# Altium schematic sheet AKK_V3.SchDoc
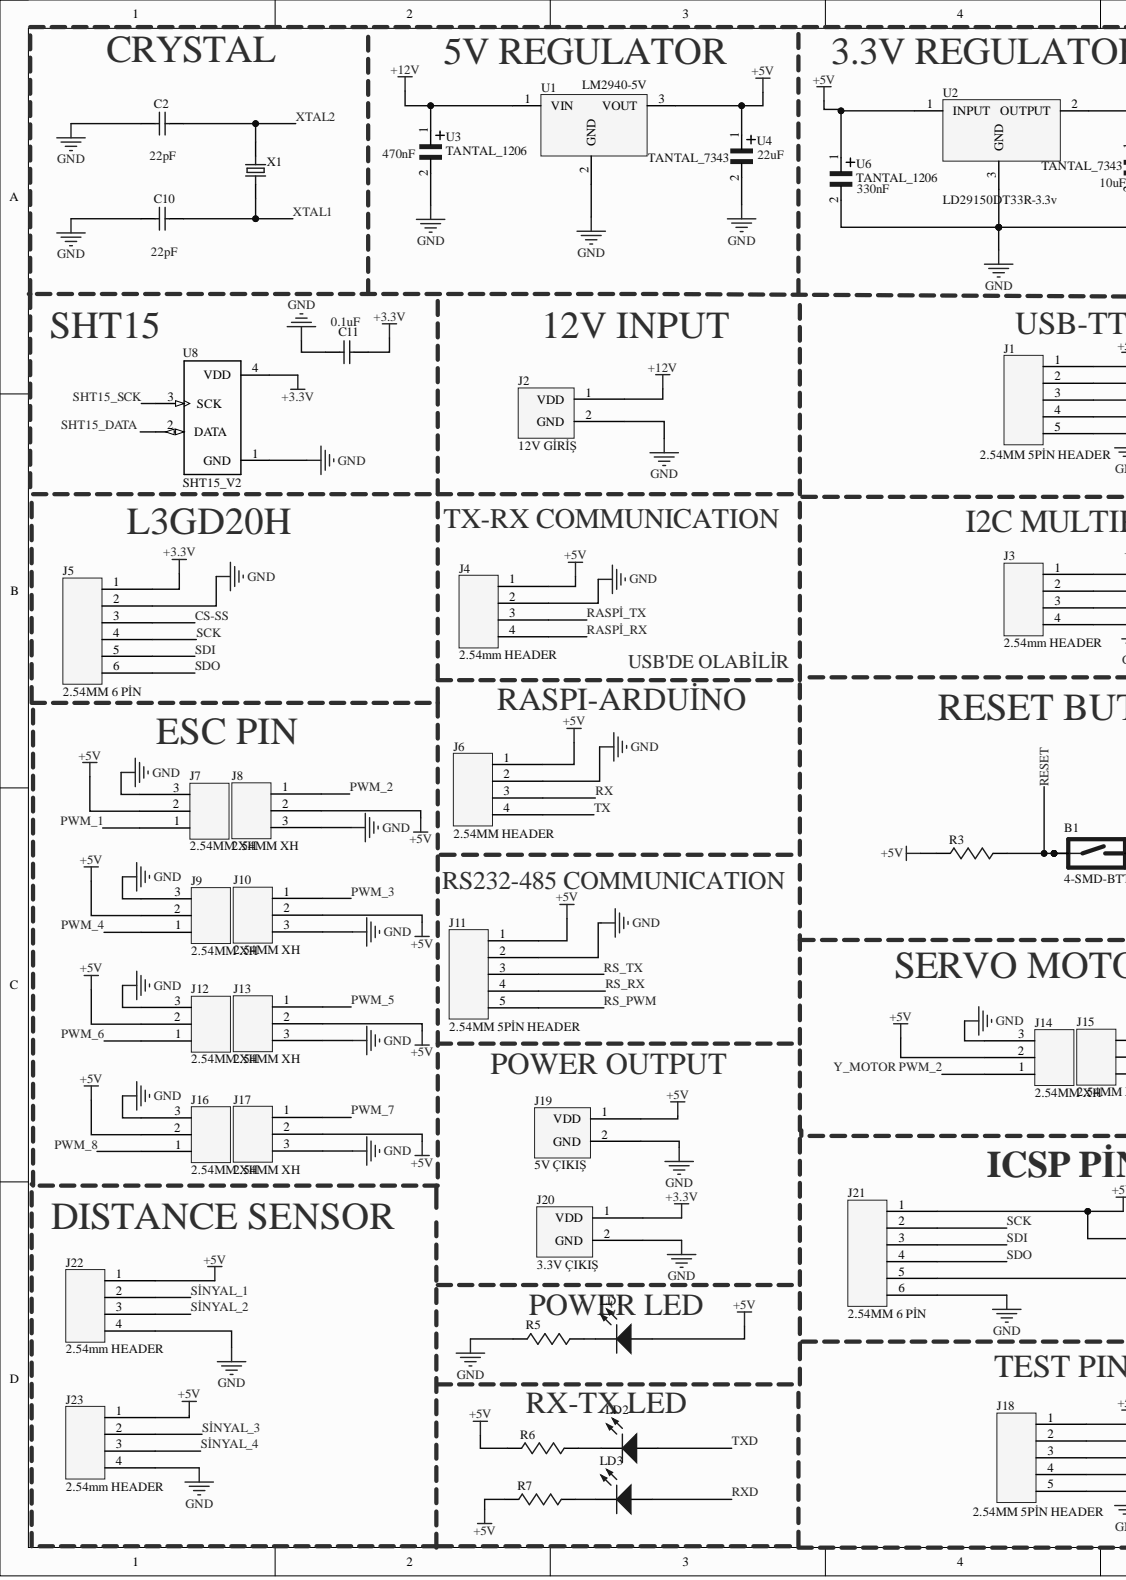
[diagram p0: AKK_V3.SchDoc, left]
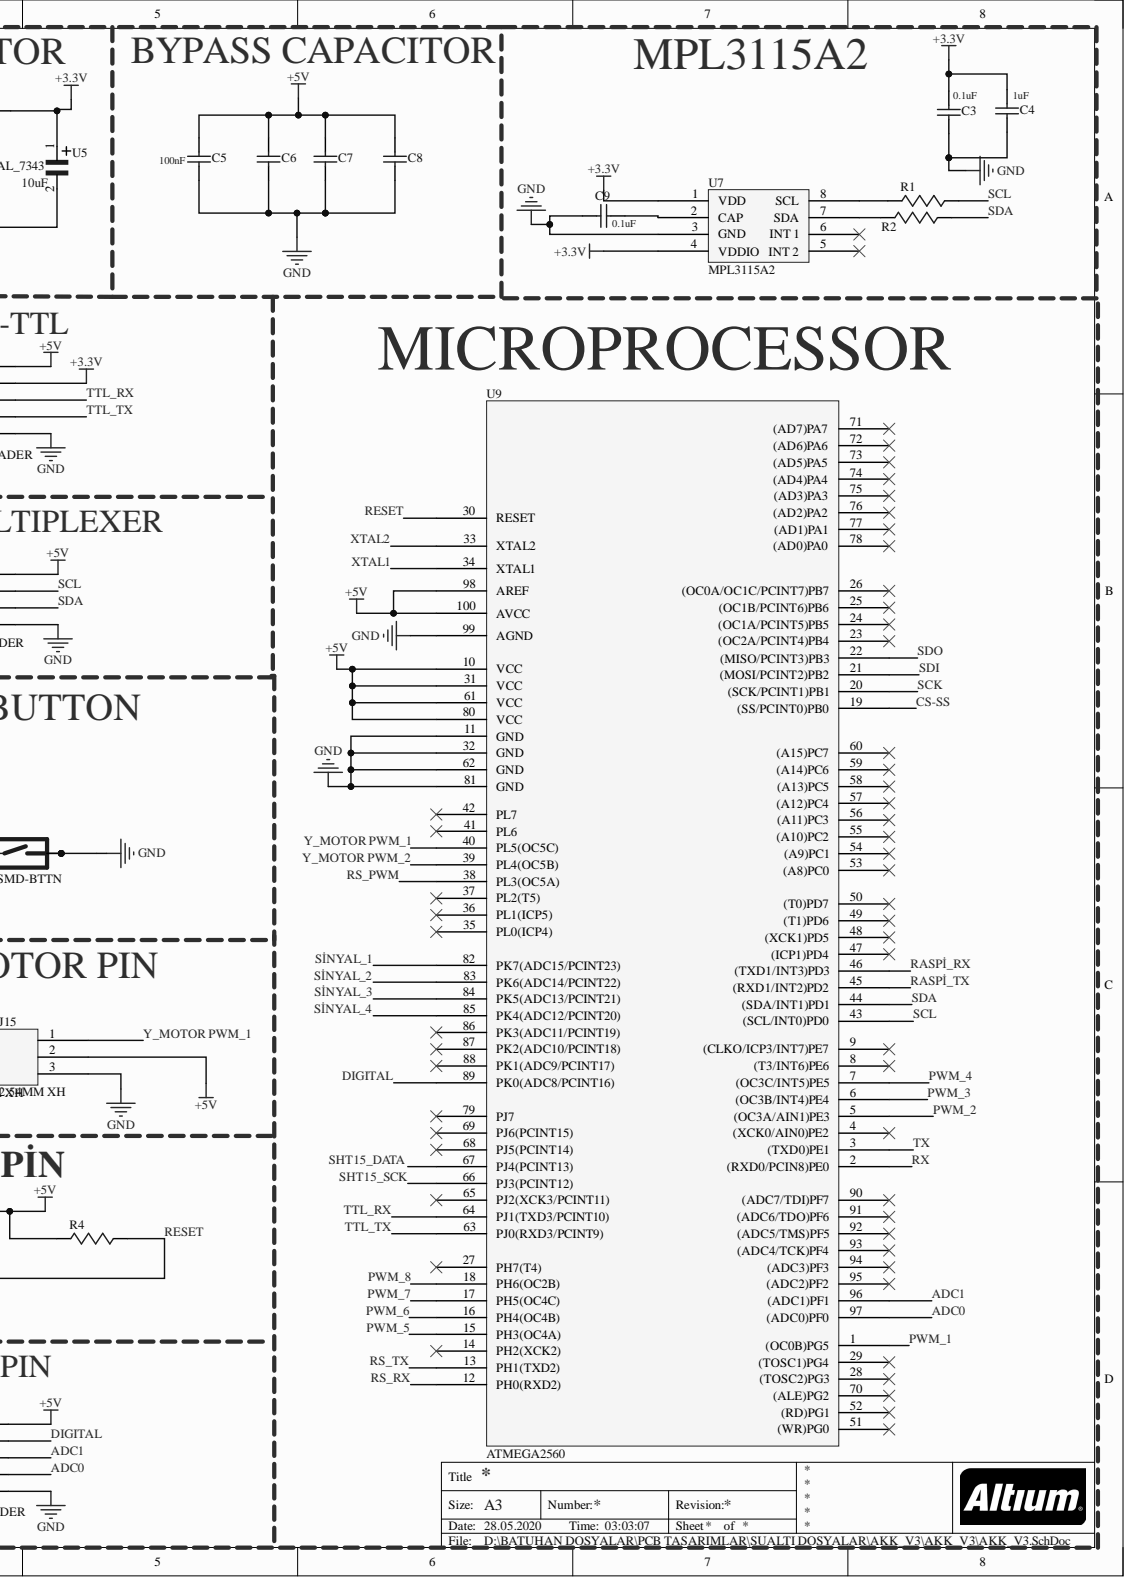
[diagram p1: AKK_V3.SchDoc, right]

## Parts
B1  4-SMD-BTTN  [4-SMD-BTTN-11.6-6.5]
C2  =Value  [C1206N]
C3  =Value  [C1206N]
C4  =Value  [C1206N]
C5  Capacitance: 100nF  [C1206N]
C6  =Value  [C1206N]
C7  =Value  [C1206N]
C8  =Value  [C1206N]
C9  =Value  [C1206N]
C10  =Value  [C1206N]
C11  =Value  [C1206N]
J1  2.54MM 5PİN HEADER  [2.54MM_5PİN_HEADER]
J2  12V GİRİŞ  [KLEMENS_5MM]
J3  2.54mm HEADER  [2.54MM_HEADER]
J4  2.54mm HEADER  [2.54MM_HEADER]
J5  2.54MM 6 PİN  [2.54mm 6 pin]
J6  2.54MM HEADER  [2.54MM_HEADER]
J7  2.54MM XH  [XH 2.54MM 3 PİN]
J8  2.54MM XH  [XH 2.54MM 3 PİN]
J9  2.54MM XH  [XH 2.54MM 3 PİN]
J10  2.54MM XH  [XH 2.54MM 3 PİN]
J11  2.54MM 5PİN HEADER  [2.54MM_5PİN_HEADER]
J12  2.54MM XH  [XH 2.54MM 3 PİN]
J13  2.54MM XH  [XH 2.54MM 3 PİN]
J14  2.54MM XH  [XH 2.54MM 3 PİN]
J15  2.54MM XH  [XH 2.54MM 3 PİN]
J16  2.54MM XH  [XH 2.54MM 3 PİN]
J17  2.54MM XH  [XH 2.54MM 3 PİN]
J18  2.54MM 5PİN HEADER  [2.54MM_5PİN_HEADER]
J19  5V ÇIKIŞ  [KLEMENS_5MM]
J20  3.3V ÇIKIŞ  [KLEMENS_5MM]
J21  2.54MM 6 PİN  [2.54mm 6 pin]
J22  2.54mm HEADER  [2.54MM_HEADER]
J23  2.54mm HEADER  [2.54MM_HEADER]
LD1  =Value  [1206-BLUE]
LD2  =Value  [1206-BLUE]
LD3  =Value  [1206-BLUE]
R1  =Value  [R1206N]
R2  =Value  [R1206N]
R3  =Value  [R1206N]
R4  =Value  [R1206N]
R5  =Value  [R1206N]
R6  =Value  [R1206N]
R7  =Value  [R1206N]
U1  LM2940-5V  Nominal Output Voltage: 5V  [TO220]
U2  LD29150DT33R-3.3v  Min Output Voltage: 3.3V  [TO252-DPAK]
U3  TANTAL_1206  [TANTAL_1206]
U4  TANTAL_7343  [TANTAL_7343]
U5  TANTAL_7343  [TANTAL_7343]
U6  TANTAL_1206  [TANTAL_1206]
U7  MPL3115A2  [MPL3115A2]
U8  SHT15_V2  [SHT15_V2]
U9  ATMEGA2560  [ATMEGA2560]
X1  =Value  [HC49]

## Nets
+3.3V: C3.2 C4.2 C11.1 J1.2 J5.1 J20.1 U2.2 U5.1 U7.1(VDD) U7.4(VDDIO) U8.4(VDD)
+5V: C5.2 C6.2 C7.2 C8.2 J1.1 J3.1 J4.1 J6.1 J7.2 J8.2 J9.2 J10.2 J11.1 J12.2 J13.2 J14.2 J15.2 J16.2 J17.2 J18.1 J19.1 J21.1 J22.1 J23.1 LD1.2 R3.1 R4.1 R6.1 R7.1 U1.3 U2.1 U4.1 U6.1 U9.10(VCC) U9.31(VCC) U9.61(VCC) U9.80(VCC) U9.98(AREF) U9.100(AVCC)
+12V: J2.1 U1.1 U3.1
GND: B1.1 B1.2 C2.2 C3.1 C4.1 C5.1 C6.1 C7.1 C8.1 C9.2 C10.2 C11.2 J1.5 J2.2 J3.4 J4.2 J5.2 J6.2 J7.3 J8.3 J9.3 J10.3 J11.2 J12.3 J13.3 J14.3 J15.3 J16.3 J17.3 J18.5 J19.2 J20.2 J21.6 J22.4 J23.4 R5.1 U1.2 U2.3 U3.2 U4.2 U5.2 U6.2 U7.3(GND) U8.1(GND) U9.11(GND) U9.32(GND) U9.62(GND) U9.81(GND) U9.99(AGND)
ADC0: J18.4 U9.97((ADC0)PF0)
ADC1: J18.3 U9.96((ADC1)PF1)
CS-SS: J5.3 U9.19((SS/PCINT0)PB0)
DIGITAL: J18.2 U9.89(PK0(ADC8/PCINT16))
PWM_1: J7.1 U9.1((OC0B)PG5)
PWM_2: J8.1 U9.5((OC3A/AIN1)PE3)
PWM_3: J10.1 U9.6((OC3B/INT4)PE4)
PWM_4: J9.1 U9.7((OC3C/INT5)PE5)
PWM_5: J13.1 U9.15(PH3(OC4A))
PWM_6: J12.1 U9.16(PH4(OC4B))
PWM_7: J17.1 U9.17(PH5(OC4C))
PWM_8: J16.1 U9.18(PH6(OC2B))
RASPİ_RX: J4.4 U9.46((TXD1/INT3)PD3)
RASPİ_TX: J4.3 U9.45((RXD1/INT2)PD2)
RESET: B1.3 B1.4 J21.5 R3.2 R4.2 U9.30(RESET)
RS_PWM: J11.5 U9.38(PL3(OC5A))
RS_RX: J11.4 U9.12(PH0(RXD2))
RS_TX: J11.3 U9.13(PH1(TXD2))
RX: J6.3 U9.2((RXD0/PCIN8)PE0)
RXD: LD3.2
SCK: J5.4 J21.2 U9.20((SCK/PCINT1)PB1)
SCL: J3.2 R1.2 U9.43((SCL/INT0)PD0)
SDA: J3.3 R2.2 U9.44((SDA/INT1)PD1)
SDI: J5.5 J21.3 U9.21((MOSI/PCINT2)PB2)
SDO: J5.6 J21.4 U9.22((MISO/PCINT3)PB3)
SHT15_DATA: U8.2(DATA) U9.67(PJ4(PCINT13))
SHT15_SCK: U8.3(SCK) U9.66(PJ3(PCINT12))
SİNYAL_1: J22.2 U9.82(PK7(ADC15/PCINT23))
SİNYAL_2: J22.3 U9.83(PK6(ADC14/PCINT22))
SİNYAL_3: J23.2 U9.84(PK5(ADC13/PCINT21))
SİNYAL_4: J23.3 U9.85(PK4(ADC12/PCINT20))
TTL_RX: J1.3 U9.64(PJ1(TXD3/PCINT10))
TTL_TX: J1.4 U9.63(PJ0(RXD3/PCINT9))
TX: J6.4 U9.3((TXD0)PE1)
TXD: LD2.2
XTAL1: C10.1 U9.34(XTAL1) X1.1
XTAL2: C2.1 U9.33(XTAL2) X1.2
Y_MOTOR PWM_1: J15.1 U9.40(PL5(OC5C))
Y_MOTOR PWM_2: J14.1 U9.39(PL4(OC5B))
NetC9_1: C9.1 U7.2(CAP)
NetLD1_1: LD1.1 R5.2
NetLD2_1: LD2.1 R6.2
NetLD3_1: LD3.1 R7.2
NetR1_1: R1.1 U7.8(SCL)
NetR2_1: R2.1 U7.7(SDA)

Not connected: U7 5(INT_2) 6(INT_1); U9 4((XCK0/AIN0)PE2) 8((T3/INT6)PE6) 9((CLKO/ICP3/INT7)PE7) 14(PH2(XCK2)) 23((OC2A/PCINT4)PB4) 24((OC1A/PCINT5)PB5) 25((OC1B/PCINT6)PB6) 26((OC0A/OC1C/PCINT7)PB7) 27(PH7(T4)) 28((TOSC2)PG3) 29((TOSC1)PG4) 35(PL0(ICP4)) 36(PL1(ICP5)) 37(PL2(T5)) 41(PL6) 42(PL7) 47((ICP1)PD4) 48((XCK1)PD5) 49((T1)PD6) 50((T0)PD7) 51((WR)PG0) 52((RD)PG1) 53((A8)PC0) 54((A9)PC1) 55((A10)PC2) 56((A11)PC3) 57((A12)PC4) 58((A13)PC5) 59((A14)PC6) 60((A15)PC7) 65(PJ2(XCK3/PCINT11)) 68(PJ5(PCINT14)) 69(PJ6(PCINT15)) 70((ALE)PG2) 71((AD7)PA7) 72((AD6)PA6) 73((AD5)PA5) 74((AD4)PA4) 75((AD3)PA3) 76((AD2)PA2) 77((AD1)PA1) 78((AD0)PA0) 79(PJ7) 86(PK3(ADC11/PCINT19)) 87(PK2(ADC10/PCINT18)) 88(PK1(ADC9/PCINT17)) 90((ADC7/TDI)PF7) 91((ADC6/TDO)PF6) 92((ADC5/TMS)PF5) 93((ADC4/TCK)PF4) 94((ADC3)PF3) 95((ADC2)PF2)

## Library data of the parts
B1 = B3FS-1012P: SWITCH TACTILE SPST-NO 0.05A 24V; Manufacturer: Omron; Actuator Length: 1.7mm; Actuator Type: Standard; Contact Resistance: 100mR; Current Rating: 50mA; Database Table Name: Buttons; Electrical Life: 300000Cycles; Operating Force: 1.47N; Switch Function: Off-Mom; Switch Travel: 0.25mm; Throw Configuration: SPST; Illumination Type: Non-Illuminated; Case/Package: SMD/SMT; Category: Tactile Switches; Component Kind: Standard; ComponentLink1Description: https://datasheet.ciiva.com/30089/en-b3fs-30089264.pdf?src-supplier=Onlinecomponents.com; ComponentLink1URL: https://datasheet.ciiva.com/30089/en-b3fs-30089264.pdf?src-supplier=Onlinecomponents.com; ComponentLink2Description: https://datasheet.ciiva.com/2901/1200910001-jpg-2901308.pdf?src-supplier=Digi-Key; ComponentLink2URL: https://datasheet.ciiva.com/2901/1200910001-jpg-2901308.pdf?src-supplier=Digi-Key; ComponentLink3Description: https://datasheet.ciiva.com/2901/en-b3fs-2901309.pdf?src-supplier=Digi-Key; ComponentLink3URL: https://datasheet.ciiva.com/2901/en-b3fs-2901309.pdf?src-supplier=Digi-Key; ComponentLink4Description: https://datasheet.ciiva.com/2901/en-b3fs-2901310.pdf?src-supplier=Digi-Key; ComponentLink4URL: https://datasheet.ciiva.com/2901/en-b3fs-2901310.pdf?src-supplier=Digi-Key; Contact Plating: Silver; Depth: 8mm; Length: 6.3mm; Library Name: MyDatabaseLib.DbLib; Manufacturer Part Number: B3FS-1012P; Max Current Rating: 50mA; Max Operating Temperature: 70°C; Max Voltage Rating (DC): 24V; Mechanical Life: 300000Cycles; Min Operating Temperature: -20°C; Mount: Surface Mount; Orientation: Straight; Package Quantity: 1; Packaging: Tape and Reel; Pricing 1: 1000=0,33775, 2000=0,33710, 4000=0,33643, 6000=0,32612, 8000=0,30625 (USD); Radiation Hardening: No; RoHS: Yes; Stock 1: 221000; Supplier 1: Avnet; Supplier Part Number 1: B3FS-1012P; Termination: Gull Wing; Voltage Rating (DC): 24V; Weight: 0.2g
C2-C4 C6-C11 = C1206C104K5RAC7210: CAP CER 0.1UF 50V X7R 1206; Manufacturer: KEMET; Capacitance: 100nF; Database Table Name: Capacitor-SMD; Dielectric Material: Ceramic; Tolerance: 10%; Case Code (Metric): 3216; Case Code (Imperial): 1206; Case/Package: 1206; Category: Ceramic Capacitors; Component Kind: Standard; ComponentLink1Description: https://datasheet.ciiva.com/16623/datasheetpn-c1206c104k5rac7210-16623777.pdf?src-supplier=Avnet+Europe; ComponentLink1URL: https://datasheet.ciiva.com/16623/datasheetpn-c1206c104k5rac7210-16623777.pdf?src-supplier=Avnet+Europe; ComponentLink2Description: https://datasheet.ciiva.com/18194/getdatasheetpartid-94372-18194154.pdf?src-supplier=Verical; ComponentLink2URL: https://datasheet.ciiva.com/18194/getdatasheetpartid-94372-18194154.pdf?src-supplier=Verical; Depth: 1.6mm; Dielectric: X7R; Height: 0.78mm; Length: 3.2mm; Library Name: MyDatabaseLib.DbLib; Manufacturer Part Number: C1206C104K5RAC7210; Max Operating Temperature: 125°C; Min Operating Temperature: -55°C; Mount: Surface Mount; Package Quantity: 10000; Packaging: Tape and Reel; Pricing 1: 10000=0,01650, 20000=0,01568 (USD); Radiation Hardening: No; RoHS: Yes; Stock 1: 70000; Supplier 1: Digi-Key; Supplier Part Number 1: 399-7357-2-ND; Termination: SMD/SMT; Thickness: 0.035inch; Voltage Rating: 50V; Voltage Rating (DC): 50V; Width: 1.6mm
C5 = C1206C104K5RAC7210: CAP CER 0.1UF 50V X7R 1206; Manufacturer: KEMET; Database Table Name: Capacitor-SMD; Dielectric Material: Ceramic; Tolerance: 10%; Case Code (Metric): 3216; Case Code (Imperial): 1206; Case/Package: 1206; Category: Ceramic Capacitors; Comment: =Value; Component Kind: Standard; ComponentLink1Description: https://datasheet.ciiva.com/16623/datasheetpn-c1206c104k5rac7210-16623777.pdf?src-supplier=Avnet+Europe; ComponentLink1URL: https://datasheet.ciiva.com/16623/datasheetpn-c1206c104k5rac7210-16623777.pdf?src-supplier=Avnet+Europe; ComponentLink2Description: https://datasheet.ciiva.com/18194/getdatasheetpartid-94372-18194154.pdf?src-supplier=Verical; ComponentLink2URL: https://datasheet.ciiva.com/18194/getdatasheetpartid-94372-18194154.pdf?src-supplier=Verical; Depth: 1.6mm; Dielectric: X7R; Height: 0.78mm; Length: 3.2mm; Library Name: MyDatabaseLib.DbLib; Manufacturer Part Number: C1206C104K5RAC7210; Max Operating Temperature: 125°C; Min Operating Temperature: -55°C; Mount: Surface Mount; Package Quantity: 10000; Packaging: Tape and Reel; Pricing 1: 10000=0,01650, 20000=0,01568 (USD); Radiation Hardening: No; RoHS: Yes; Stock 1: 70000; Supplier 1: Digi-Key; Supplier Part Number 1: 399-7357-2-ND; Termination: SMD/SMT; Thickness: 0.035inch; Voltage Rating: 50V; Voltage Rating (DC): 50V; Width: 1.6mm
J2 J19 J20 = KLEMENS: Component Kind: Standard; Library Name: KLEMENS_5MM.SchLib
LD1-LD3 = APTR3216PBC/A: LED BLUE CLEAR 1206 SMD; Manufacturer: Kingbright; Database Table Name: Diode-SMD; Dominant Wavelength: 470nm; Forward Current: 20mA; Forward Voltage: 3.2V; Lens Color: Colorless; Luminous Intensity: 60mcd; Number of Elements: 1; Power Dissipation: 75mW; Reverse Voltage: 5V; Viewing Angle: 120°; Wavelength: 639nm; Case Code (Metric): 3216; Case Code (Imperial): 1206; Case/Package: 1206; Category: LEDs; Color: Blue; Component Kind: Standard; ComponentLink1Description: https://datasheet.ciiva.com/2114/aptr3216pbc-a-2114354.pdf?src-supplier=Digi-Key; ComponentLink1URL: https://datasheet.ciiva.com/2114/aptr3216pbc-a-2114354.pdf?src-supplier=Digi-Key; ComponentLink2Description: https://datasheet.ciiva.com/4691/aptr3216pbc-a-7371-4691743.pdf?src-supplier=Mouser; ComponentLink2URL: https://datasheet.ciiva.com/4691/aptr3216pbc-a-7371-4691743.pdf?src-supplier=Mouser; ComponentLink3Description: https://datasheet.ciiva.com/2114/aptr3216pbc-a-2114355.pdf?src-supplier=Digi-Key; ComponentLink3URL: https://datasheet.ciiva.com/2114/aptr3216pbc-a-2114355.pdf?src-supplier=Digi-Key; ComponentLink4Description: https://datasheet.ciiva.com/2114/aptr3216pbc-a-2114356.pdf?src-supplier=Digi-Key; ComponentLink4URL: https://datasheet.ciiva.com/2114/aptr3216pbc-a-2114356.pdf?src-supplier=Digi-Key; ComponentLink5Description: https://datasheet.ciiva.com/15117/getdatasheetpartid-144017-15117804.pdf?src-supplier=Verical; ComponentLink5URL: https://datasheet.ciiva.com/15117/getdatasheetpartid-144017-15117804.pdf?src-supplier=Verical; ComponentLink6Description: https://datasheet.ciiva.com/12400/lite-on-ltst-c230krkt-187437-12400814.pdf?src-supplier=Mouser; ComponentLink6URL: https://datasheet.ciiva.com/12400/lite-on-ltst-c230krkt-187437-12400814.pdf?src-supplier=Mouser; ComponentLink7Description: https://datasheet.ciiva.com/16444/ltst-c230krkt-16444734.pdf?src-supplier=Future+Electronics; ComponentLink7URL: https://datasheet.ciiva.com/16444/ltst-c230krkt-16444734.pdf?src-supplier=Future+Electronics; ComponentLink8Description: https://datasheet.ciiva.com/4266/dwonloadfilehandler-ashxtxtspecnods22-2000-253-ashxtxtspecnods22-2000-253-4266917.pdf?src-supplier=Arrow; ComponentLink8URL: https://datasheet.ciiva.com/4266/dwonloadfilehandler-ashxtxtspecnods22-2000-253-ashxtxtspecnods22-2000-253-4266917.pdf?src-supplier=Arrow; ComponentLink9URL: https://datasheet.ciiva.com/11774/0900766b80dc057b-11774044.pdf?src-supplier=RSComponents; Depth: 1.6mm; Height: 1.05mm; Lead Free: Lead Free; Length: 3.2mm; Lens Style: Clear; Lens Transparency: Clear; Library Name: MyDatabaseLib.DbLib; Manufacturer Part Number: APTR3216PBC/A; Max Operating Temperature: 85°C; Min Operating Temperature: -40°C; Mount: Surface Mount; Number of LEDs: 1; Package Quantity: 2000; Packaging: Tape and Reel; Power Rating: 75mW; Pricing 1: 1=0,59000, 10=0,45600, 100=0,29250, 500=0,25156, 1000=0,19422 (USD); Radiation Hardening: No; RoHS: Yes; Stock 1: 128414; Supplier 1: Digi-Key; Supplier Part Number 1: 754-1169-1-ND; Test Current: 20mA; Width: 1.6mm; Illumination Color: Blue
R1-R7 = HRG3216P-1001-B-T1: RES SMD 1K OHM 0.1% 1W 1206; Manufacturer: Susumu; Database Table Name: Resistors-SMD; Resistance: 1kR; Temperature Coefficient: 25ppm/°C; Case Code (Metric): 3216; Case Code (Imperial): 1206; Case/Package: 1206; Category: Chip SMD Resistors; Component Kind: Standard; Composition: Thin Film; Library Name: MyDatabaseLib.DbLib; Manufacturer Part Number: HRG3216P-1001-B-T1; Max Operating Temperature: 125°C; Max Power Dissipation: 1W; Min Operating Temperature: -55°C; Number of Terminations: 2; Packaging: Tape and Reel; Pricing 1: 1000=0,55000, 2000=0,45000, 3000=0,44500, 4000=0,44000 (USD); RoHS: Yes; Stock 1: 1000; Supplier 1: Future Electronics; Supplier Part Number 1: HRG3216P-1001-B-T1-REEL; Tolerance: 0.1%
U1 = LM2940T-5.0/NOPB: TEXAS INSTRUMENTS         LM2940T-5.0/NOPB            Fixed LDO Voltage Regulator, 0V to 26V, 500mV Dropout, 5Vout, 1Aout, TO-220-3; Manufacturer: TI National Semiconductor; Database Table Name: Regulators; Max Output Current: 1A; Min Input Voltage: 6.25V; Number of Outputs: 1; Polarity: Positive; Quiescent Current: 15mA; Case/Package: TO-220; Category: Voltage Regulators - Linear; Component Kind: Standard; ComponentLink1Description: https://datasheet.ciiva.com/19477/837505-19477731.pdf?src-supplier=Jameco; ComponentLink1URL: https://datasheet.ciiva.com/19477/837505-19477731.pdf?src-supplier=Jameco; ComponentLink2Description: https://datasheet.ciiva.com/15111/getdatasheetpartid-97895-15111678.pdf?src-supplier=Verical; ComponentLink2URL: https://datasheet.ciiva.com/15111/getdatasheetpartid-97895-15111678.pdf?src-supplier=Verical; ComponentLink3Description: https://datasheet.ciiva.com/41458/001014007-da-01-en-ic-reg-ldo-lm2940t-5-0-nopb-to-220-3-tid-41458133.pdf?src-supplier=Conrad; ComponentLink3URL: https://datasheet.ciiva.com/41458/001014007-da-01-en-ic-reg-ldo-lm2940t-5-0-nopb-to-220-3-tid-41458133.pdf?src-supplier=Conrad; ComponentLink4Description: https://datasheet.ciiva.com/1511/1882643-1511796.pdf?src-supplier=Element14; ComponentLink4URL: https://datasheet.ciiva.com/1511/1882643-1511796.pdf?src-supplier=Element14; ComponentLink5Description: https://datasheet.ciiva.com/6595/lm2940-n-6595369.pdf?src-supplier=Digi-Key; ComponentLink5URL: https://datasheet.ciiva.com/6595/lm2940-n-6595369.pdf?src-supplier=Digi-Key; ComponentLink6Description: https://datasheet.ciiva.com/16466/lm2940c-16466841.pdf?src-supplier=Avnet+Asia; ComponentLink6URL: https://datasheet.ciiva.com/16466/lm2940c-16466841.pdf?src-supplier=Avnet+Asia; ComponentLink7Description: https://datasheet.ciiva.com/3223/lm2940c-3223630.pdf?src-supplier=Avnet; ComponentLink7URL: https://datasheet.ciiva.com/3223/lm2940c-3223630.pdf?src-supplier=Avnet; Contact Plating: Tin,Matte; Dropout Voltage: 500mV; Height: 8.89mm; Lead Free: Lead Free; Length: 10.16mm; Library Name: MyDatabaseLib.DbLib; Manufacturer Part Number: LM2940T-5.0/NOPB; Max Operating Temperature: 125°C; Max Input Voltage: 26V; Min Operating Temperature: -40°C; Mount: Through Hole; Number of Pins: 3; Output Current: 1A; Output Type: Fixed; Output Voltage: 5V; Package Quantity: 45; Packaging: Rail/Tube; Pricing 1: 1=1,40000, 10=1,19000, 100=0,95400, 250=0,83200, 500=0,80800, 1000=0,64200, 2500=0,61800, 1=1,56000, 10=1,32000, 100=1,06000, 250=0,92400, 500=0,89800, 1000=0,71300 (EUR); Radiation Hardening: No; REACH SVHC: No SVHC; RoHS: Yes; Stock 1: 4213; Supplier 1: Mouser; Supplier Part Number 1: 926-LM2940T-5.0/NOPB; Voltage: 5V; Weight: 0.01lb; Width: 4.7mm
U2 = LD29150DT33R: STMICROELECTRONICS         LD29150DT33R             Fixed LDO Voltage Regulator, 4.3V to 30V, 400mV Dropout, 3.3Vout, 1.5Aout, TO-252-3; Accuracy: 1%; Database Table Name: Regulators; Max Output Current: 1.5A; Max Output Voltage: 3.333V; Number of Outputs: 1; Polarity: Positive; Quiescent Current: 15mA; Reference Voltage: 1.2423V; Case/Package: TO-252; Category: Voltage Regulators - Linear; Component Kind: Standard; ComponentLink1Description: https://datasheet.ciiva.com/1569/1788499-1569956.pdf?src-supplier=Farnell; ComponentLink1URL: https://datasheet.ciiva.com/1569/1788499-1569956.pdf?src-supplier=Farnell; ComponentLink2Description: https://datasheet.ciiva.com/41440/001184976-da-01-en-ic-reg-ldo-3-3-ld29150dt33r-to-252-3-stm-41440118.pdf?src-supplier=Conrad; ComponentLink2URL: https://datasheet.ciiva.com/41440/001184976-da-01-en-ic-reg-ldo-3-3-ld29150dt33r-to-252-3-stm-41440118.pdf?src-supplier=Conrad; ComponentLink3Description: https://datasheet.ciiva.com/26904/ld29150dt33r-26904424.pdf?src-supplier=IIIC; ComponentLink3URL: https://datasheet.ciiva.com/26904/ld29150dt33r-26904424.pdf?src-supplier=IIIC; ComponentLink4Description: https://datasheet.ciiva.com/2044/cd00003403-2044032.pdf?src-supplier=Digi-Key; ComponentLink4URL: https://datasheet.ciiva.com/2044/cd00003403-2044032.pdf?src-supplier=Digi-Key; ComponentLink5Description: https://datasheet.ciiva.com/2044/cd00003403-2044033.pdf?src-supplier=Digi-Key; ComponentLink5URL: https://datasheet.ciiva.com/2044/cd00003403-2044033.pdf?src-supplier=Digi-Key; ComponentLink6Description: https://datasheet.ciiva.com/22694/1904189-22694855.pdf?src-supplier=Element14; ComponentLink6URL: https://datasheet.ciiva.com/22694/1904189-22694855.pdf?src-supplier=Element14; ComponentLink7Description: https://datasheet.ciiva.com/2044/cd00003403-2044031.pdf?src-supplier=Digi-Key; ComponentLink7URL: https://datasheet.ciiva.com/2044/cd00003403-2044031.pdf?src-supplier=Digi-Key; Contact Plating: Tin,Matte; Dropout Voltage: 400mV; Height: 2.4mm; Lead Free: Lead Free; Length: 6.6mm; Library Name: MyDatabaseLib.DbLib; Manufacturer: STMicroelectronics; Manufacturer Part Number: LD29150DT33R; Max Operating Temperature: 125°C; Max Supply Voltage: 30V; Max Input Voltage: 30V; Min Operating Temperature: -40°C; Min Supply Voltage: 4.3V; Min Input Voltage: 4.3V; Mount: Surface Mount; Nominal Output Voltage: 3.3V; Number of Pins: 3; Number of Regulators: 1; Output Current: 1.5A; Output Type: Fixed; Output Voltage: 3.3V; Output Voltage Accuracy: 1%; Package Quantity: 1; Packaging: Tape and Reel; Pricing 1: 1=1,46000, 10=1,24000, 100=0,84200, 500=0,79700, 1000=0,62000, 2500=0,58900 (USD); Radiation Hardening: No; REACH SVHC: No SVHC; RoHS: Yes; Stock 1: 10287; Supplier 1: Mouser; Supplier Part Number 1: 511-LD29150DT33R; Termination: SMD/SMT; Weight: 0.01lb; Width: 6.2mm; Input Bias Current: 15mA
X1 = FC4SDCBMF25.0-T1: Crystal 25MHz ±30ppm (Tol) ±50ppm (Stability) 20pF 2-Pin HC-49/SDLF SMD T/R; Manufacturer: Fox Electronics; Database Table Name: Crystals; Component Kind: Standard; ComponentLink1Description: http://www.foxonline.com/pdfs/C4SD.pdf?src-supplier=Digi-Key; ComponentLink1URL: http://www.foxonline.com/pdfs/C4SD.pdf?src-supplier=Digi-Key; Library Name: MyDatabaseLib.DbLib; Manufacturer Part Number: FC4SDCBMF25.0-T1; Pricing 1: 1=0,28000, 50=0,21500, 100=0,20500, 250=0,19000, 500=0,18100 (USD); RoHS: No; Stock 1: 9000; Supplier 1: Future Electronics; Supplier Part Number 1: FC4SDCBMF25.0-T1-CUTT
J1 J11 J18 = 2.54MM 5PİN HEADER: Component Kind: Standard; Library Name: 2.54mm__5PİN_HEADER.SchLib
J3 J4 J6 J22 J23 = 2.54mm HEADER: Component Kind: Standard; Library Name: 2.54mm__HEADER.SchLib
J5 J21 = 2.54MM 6 PİN: Component Kind: Standard; Library Name: 2.54mm__6 pin.SchLib
J7-J10 J12-J17 = 2.54MM XH: Component Kind: Standard; Library Name: XH 2.54MM 3 PİN.SchLib
U3 U6 = TANTAL_1206: Component Kind: Standard; Library Name: TANTAL_1206.SchLib
U4 U5 = TANTAL_7343: Component Kind: Standard; Library Name: 7343_TANTAL.SchLib
U7 = MPL3115A2: Component Kind: Standard; Library Name: MPL3115A2.SchLib
U8 = SHT15_V2: Component Kind: Standard; Library Name: SHT15_V2.SchLib
U9 = ATMEGA2560: Component Kind: Standard; Library Name: ATMEGA2560.SchLib
